# Altium schematic sheet Power.SchDoc
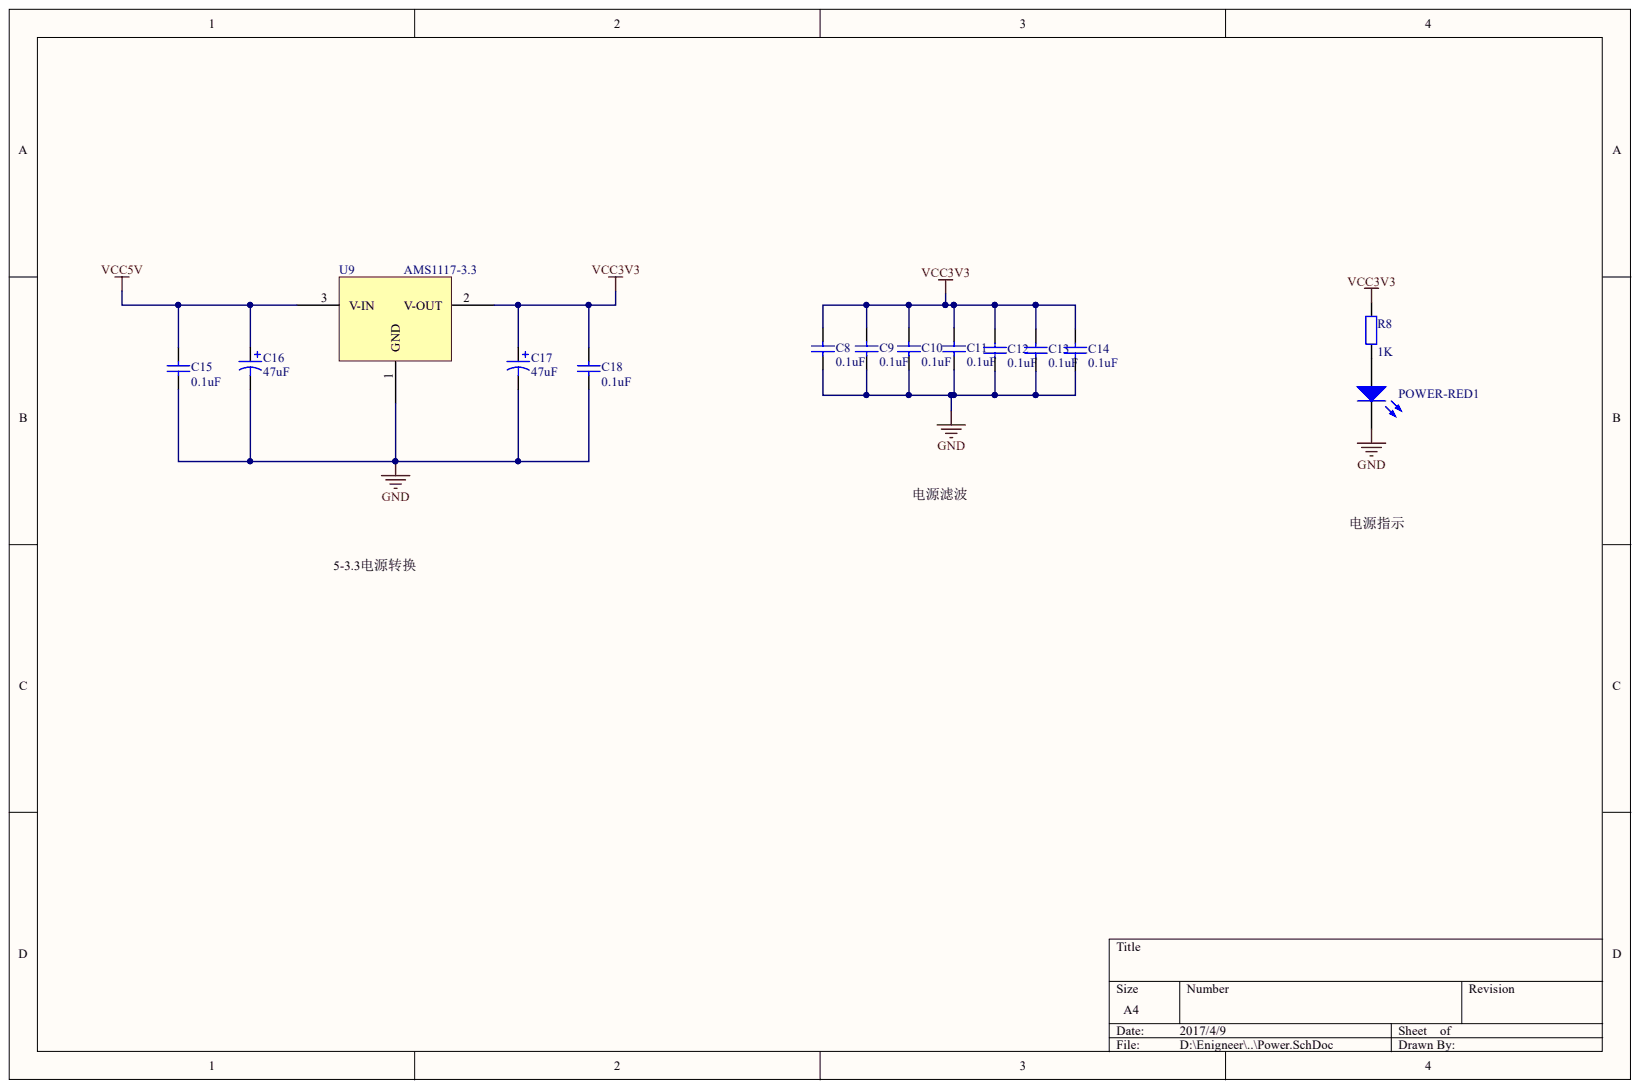
[diagram p0: Power.SchDoc]

## Parts
C8  value 0.1uF  [0603C]  (hidden comment: Cap)
C9  value 0.1uF  [0603C]  (hidden comment: Cap)
C10  value 0.1uF  [0603C]  (hidden comment: Cap)
C11  value 0.1uF  [0603C]  (hidden comment: Cap)
C12  value 0.1uF  [0603C]  (hidden comment: Cap)
C13  value 0.1uF  [0603C]  (hidden comment: Cap)
C14  value 0.1uF  [0603C]  (hidden comment: Cap)
C15  value 0.1uF  [0603C]  (hidden comment: Cap)
C16  value 47uF  [AVX 3528 CAP]  (hidden comment: Cap Pol1)
C17  value 47uF  [AVX 3528 CAP]  (hidden comment: Cap Pol1)
C18  value 0.1uF  [0603C]  (hidden comment: Cap)
POWER-RED1  LED0  [Diode-0805]  (value not drawn)
R8  value 1K  [0603R]  (hidden comment: Res2)
U9  AMS1117-3.3  [AMS1117-SOT223]

## Nets
GND: C8.1 C9.1 C10.1 C11.1 C12.1 C13.1 C14.1 C15.1 C16.2 C17.2 C18.2 POWER-RED1.2 U9.1
VCC3V3: C8.2 C9.2 C10.2 C11.2 C12.2 C13.2 C14.2 C17.1 C18.1 R8.2 U9.2
VCC5V: C15.2 C16.1 U9.3
NetPOWER-RED1_1: POWER-RED1.1 R8.1
5-3.3电源转换:
电源指示:
电源滤波:

## Library data of the parts
C8-C15 C18 = Cap: Capacitor; Component Kind: Standard; LatestRevisionDate: 17-Jul-2002; LatestRevisionNote: Re-released for DXP Platform.; Library Name: Miscellaneous Devices.IntLib; PackageDescription: Radial Cap, Thru-Hole; 2 Leads; 0.3 in Pin Spacing; PackageReference: RAD-0.3; Published: 8-Jun-2000; Signal Integrity: Cap; Simulation: CAP; Publisher: Altium Limited
C16 C17 = Cap Pol1: Polarized Capacitor (Radial); Component Kind: Standard; LatestRevisionDate: 17-Jul-2002; LatestRevisionNote: Re-released for DXP Platform.; Library Name: Miscellaneous Devices.IntLib; PackageDescription: Polarized Capacitor (Radial); 2 Leads; PackageReference: RB7.6-15; Published: 8-Jun-2000; Signal Integrity: Cap Pol; Simulation: CAP; Publisher: Altium Limited
POWER-RED1 = LED0: Typical INFRARED GaAs LED; Component Kind: Standard; LatestRevisionDate: 08-Jul-2005; LatestRevisionNote: Mech Layer 1 removed.; Library Name: Miscellaneous Devices.IntLib; PackageDescription: LED; 2 Leads; PackageReference: LED-0; Published: 8-Jun-2000; Simulation: LED0; Publisher: Altium Limited
R8 = Res2: Resistor; Component Kind: Standard; LatestRevisionDate: 17-Jul-2002; LatestRevisionNote: Re-released for DXP Platform.; Library Name: Miscellaneous Devices.IntLib; PackageDescription: Axial Device, Thru-Hole; 2 Leads; 0.4 in Pin Spacing; PackageReference: AXIAL-0.4; Published: 8-Jun-2000; Signal Integrity: Res; Simulation: RESISTOR; Publisher: Altium Limited
U9 = AMS1117-3.3: Component Kind: Standard; Library Name: pxLib.SchLib
